# Altium schematic sheet Driver.SchDoc(Driver)
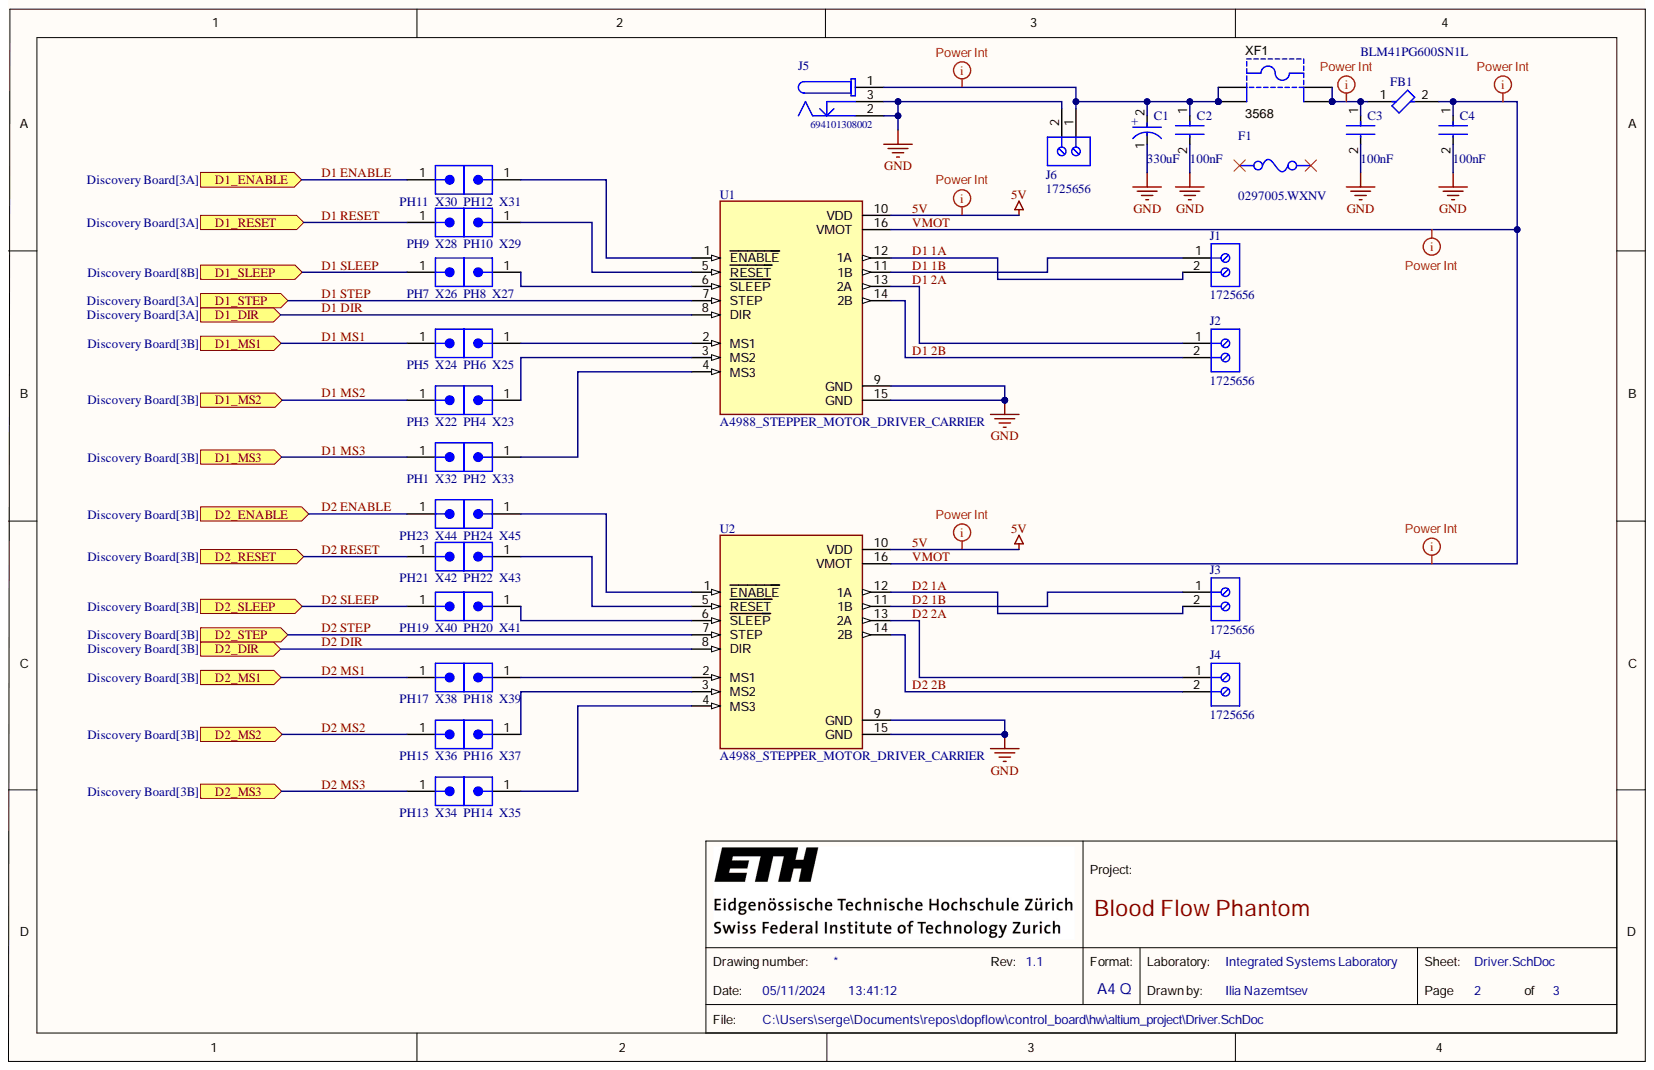
[diagram p0: Driver.SchDoc]

## Parts
C1  330uF  [FP-RAD-TH-D_10_0_5-L_12_5-MFG]
C2  100nF  [FP-CL31-IPC_C]
C3  100nF  [FP-CL31-IPC_C]
C4  100nF  [FP-CL31-IPC_C]
F1  0297005.WXNV  [FP-0297-MFG]
FB1  BLM41PG600SN1L  [FP-BLM41-0_2-IPC_B]
J1  1725656  [PHOE-1725656-2]
J2  1725656  [PHOE-1725656-2]
J3  1725656  [PHOE-1725656-2]
J4  1725656  [PHOE-1725656-2]
J5  694101308002  [694101308002]
J6  1725656  [PHOE-1725656-2]
U1  A4988_STEPPER_MOTOR_DRIVER_CARRIER  [MODULE_A4988_STEPPER_MOTOR_DRIVER_CARRIER]
U2  A4988_STEPPER_MOTOR_DRIVER_CARRIER  [MODULE_A4988_STEPPER_MOTOR_DRIVER_CARRIER]
X22  PH3  [68000-101HLF]
X23  PH4  [68000-101HLF]
X24  PH5  [68000-101HLF]
X25  PH6  [68000-101HLF]
X26  PH7  [68000-101HLF]
X27  PH8  [68000-101HLF]
X28  PH9  [68000-101HLF]
X29  PH10  [68000-101HLF]
X30  PH11  [68000-101HLF]
X31  PH12  [68000-101HLF]
X32  PH1  [68000-101HLF]
X33  PH2  [68000-101HLF]
X34  PH13  [68000-101HLF]
X35  PH14  [68000-101HLF]
X36  PH15  [68000-101HLF]
X37  PH16  [68000-101HLF]
X38  PH17  [68000-101HLF]
X39  PH18  [68000-101HLF]
X40  PH19  [68000-101HLF]
X41  PH20  [68000-101HLF]
X42  PH21  [68000-101HLF]
X43  PH22  [68000-101HLF]
X44  PH23  [68000-101HLF]
X45  PH24  [68000-101HLF]
XF1  3568  [KSTN-3568_V]

## Ports
D1_DIR, D1_ENABLE, D1_MS1, D1_MS2, D1_MS3, D1_RESET, D1_SLEEP, D1_STEP, D2_DIR, D2_ENABLE, D2_MS1, D2_MS2, D2_MS3, D2_RESET, D2_SLEEP, D2_STEP

## Nets
GND: C1.1 C2.2 C3.2 C4.2 J5.2 J5.3 J6.2 U1.9(GND) U1.15(GND) U2.9(GND) U2.15(GND)
5V: U1.10(VDD) U2.10(VDD)
D1 1A: J1.2 U1.12(1A)
D1 1B: J1.1 U1.11(1B)
D1 2A: J2.1 U1.13(2A)
D1 2B: J2.2 U1.14(2B)
D1 DIR: U1.8(DIR) ; port D1_DIR
D1 ENABLE: X30.1 ; port D1_ENABLE
D1 MS1: X24.1 ; port D1_MS1
D1 MS2: X22.1 ; port D1_MS2
D1 MS3: X32.1 ; port D1_MS3
D1 RESET: X28.1 ; port D1_RESET
D1 SLEEP: X26.1 ; port D1_SLEEP
D1 STEP: U1.7(STEP) ; port D1_STEP
D2 1A: J3.2 U2.12(1A)
D2 1B: J3.1 U2.11(1B)
D2 2A: J4.1 U2.13(2A)
D2 2B: J4.2 U2.14(2B)
D2 DIR: U2.8(DIR) ; port D2_DIR
D2 ENABLE: X44.1 ; port D2_ENABLE
D2 MS1: X38.1 ; port D2_MS1
D2 MS2: X36.1 ; port D2_MS2
D2 MS3: X34.1 ; port D2_MS3
D2 RESET: X42.1 ; port D2_RESET
D2 SLEEP: X40.1 ; port D2_SLEEP
D2 STEP: U2.7(STEP) ; port D2_STEP
VMOT: C4.1 FB1.2 U1.16(VMOT) U2.16(VMOT)
NetC1_2: C1.2 C2.1 J5.1 J6.1 XF1.1 XF1.2
NetC3_1: C3.1 FB1.1 XF1.3 XF1.4
NetU1_1: U1.1(ENABLE) X31.1
NetU1_2: U1.2(MS1) X25.1
NetU1_3: U1.3(MS2) X23.1
NetU1_4: U1.4(MS3) X33.1
NetU1_5: U1.5(RESET) X29.1
NetU1_6: U1.6(SLEEP) X27.1
NetU2_1: U2.1(ENABLE) X45.1
NetU2_2: U2.2(MS1) X39.1
NetU2_3: U2.3(MS2) X37.1
NetU2_4: U2.4(MS3) X35.1
NetU2_5: U2.5(RESET) X43.1
NetU2_6: U2.6(SLEEP) X41.1

## Library data of the parts
C1 = CMP-05427-001459-1: Aluminum Electrolytic Capacitors (Radial Lead Type) 330uF ±20% 35V; Impedance: 43mR; Lead Diameter: 0.6mm; Life (Hours): 6000Hour; Ripple Current: 1.33A; Capacitance: 330uF; Case/Package: Radial; Component Kind: Standard; Construction: RADIAL; Diameter: 10mm; Height: 12.5mm; Lead Pitch: 5mm; Library Name: Altium Content Vault; Max Operating Temperature: 105°C; Min Operating Temperature: -40°C; Packaging: Bulk; REACH SVHC: No SVHC; Ripple Current (AC): 1.33A; RoHS Compliant: Yes; Series: FR Radial; Termination: Radial; Tolerance: 20%; Voltage: 35V; Voltage Rating: 35V
C2-C4 = CMP-13271-001731-1: Cap Ceramic 100nF 50V X7R ±10% SMD 1206 +125°C Embossed T/R; Capacitance: 100nF; Case/Package: 1206; Component Kind: Standard; Depth: 1.6mm; Dielectric: X7R; Height: 0.85mm; Lead Free: Lead Free; Length: 3.2mm; Library Name: Altium Content Vault; Max Operating Temperature: 125°C; Min Operating Temperature: -55°C; Mount: Surface Mount; Package Quantity: 15000; Packaging: Tape and Reel; Radiation Hardening: No; Thickness: 0.037inch; Tolerance: 10%; Voltage: 50V; Voltage Rating: 50V; Voltage Rating (DC): 50V; Width: 0.063inch
F1 = CMP-08605-000073-1: FUSE AUTO 5A 32VDC BLADE MINI; Manufacturer: Littelfuse Inc; Fuse Blow Speed: Fast; Fuse I2T: 25A2Sec; Fuse Interrupt Dc Current: 1000A; Fuse Voltage Drop: 129mV; Max Fuse Dc Voltage: 32V; Resistance: 17.75mΩ; Value: 17.75mΩ; Automotive: Yes; Category: Fuse; Component Kind: Standard; ComponentLink2Description: Datasheet; ComponentLink2URL: https://www.littelfuse.com/~/media/automotive/datasheets/fuses/passenger-car-and-commercial-vehicle/blade-fuses/littelfuse_mini_datasheet.pdf; Device Class L1: Circuit Protection; Device Class L2: Fuses; Device Class L3: unset; Digikey Description: FUSE AUTO 5A 32VDC BLADE MINI; Fuse Current: 5A; Lead Free: Yes; Library Name: Altium Content Vault; Manufacturer Part Number: 0297005.WXNV; Mouser Description: Automotive Fuses 32V 5A Mini; Octopart: https://octopart.com/0297005.wxnv-littelfuse-566870; Package: 0297; RoHS: TRUE; Temperature: -40°C to +125°C; Temperature Range High: +125°C; Temperature Range Low: -40°C; Voltage Rating: 32V
FB1 = CMP-0686-00754-3: Ferrite Bead, 1806, 60Ω @ 100MHz, 0.009Ω, 6A; Manufacturer Series: BLM41P; DC Resistance (DCR): 9mR; Impedance: 60R; Number of Circuits: 1; Shielding: Unshielded; Tolerance: 25%; Case Code (Imperial): 1806; Case Code (Metric): 4516; Case/Package: 1806; Component Kind: Standard; Contact Plating: Tin; Core Material: Ferrite; Current: 6A; Current Rating: 6A; DC Current: 6A; DC Resistance-Max: 9mR; Depth: 1.6mm; Frequency: 100MHz; Height: 1.6mm; Inductor Type: FERRITE BEAD; Lead Free: Lead Free; Length: 4.5mm; Library Name: Altium Content Vault; Material: Ferrite; Max Current Rating: 6A; Max DC Current: 6A; Max Operating Temperature: 125°C; Military Standard: Not; Min Operating Temperature: -55°C; Number of Functions: 1; Number of Lines: 1; Number of Pins: 2; Package Quantity: 1; Packaging: Tape and Reel; Radiation Hardening: No; REACH SVHC: No SVHC; RoHS Compliant: Yes; Series: BLM41P; Terminal Finish: Tin; Termination: SMD/SMT; Test Frequency: 100MHz; Width: 1.6mm
J1-J4 J6 = CMP-2000-05299-1: Male Header, Pitch 2.54 mm, 1 x 2 Position, Height 9 mm, Tail Length 3.5 mm; Manufacturer: Phoenix Contact; Content: 250pc(s); Industrial packaging: Yes; Number of contacts: 2; Pitch: 2.54mm; Colour: Green; Component Kind: Standard; Datasheet URL: http://datasheet.octopart.com/1725656-Phoenix-Contact-datasheet-5302866.pdf; Datasheet Version: Apr-08; Library Name: Altium Content Vault; Manufacturer part No.: 1725656; Manufacturer URL: http://www.phoenixcontact.com/; Type: MPT0,5/2-2,54
J5 = CMP-1502-00182-1: DC Power Jack Connector Low Profile WR-DC, Male, Right Angled, THT, 2 A, 12 V; Manufacturer: Wurth Elektronik; Opening Diameter: 2.8mm; Plug Inner Diameter: 0.75mm; Plug Outer Diameter: 2.35mm; Rated Current: 2A; Working Voltage: 12V; Component Kind: Standard; Datasheet URL: http://katalog.we-online.de/em/datasheet/694101308002.pdf; Gender: Male; Library Name: Altium Content Vault; Manufacturer URL: http://www.we-online.de; Mounting Technology: THT; Operating Temperature: -40to85degC; Orientation: RightAngled; Package Reference: 694101308002; Packaging: Bag; Part Number: 694101308002; Publisher: WurthElektronik
U1 U2 = A4988_STEPPER_MOTOR_DRIVER_CARRIER: Stepper motor controler; IC: A4988; 1A; Uin mot: 8Ã·35V; Availability: Unavailable; Component Kind: Standard; Library Name: A4988_STEPPER_MOTOR_DRIVER_CARRIER.SchLib; MP: A4988_STEPPER_MOTOR_DRIVER_CARRIER; Package: None; Price: None
X22-X45 = CMP-00008-5: 1 Positions Header, Unshrouded Connector  Through Hole Gold or Gold, GXT™; Manufacturer: Amphenol FCI; Connector Type: Male Pin; Current Rating: 3A; Gender: Male; Insulation Resistance: 5GR; Mating Post Length: 5.84mm; Max Voltage Rating (AC): 1.5kV; Number of Contacts: 1; Pitch: 2.54mm; Value: 1000Meg; Colour: Black; Component Kind: Standard; ComponentLink1Description: 68000-274334-5147816.pdf; ComponentLink1URL: https://datasheet.ciiva.com/5147/68000-274334-5147816.pdf; ComponentLink2Description: 70236886-14643004.pdf; ComponentLink2URL: https://datasheet.ciiva.com/14643/70236886-14643004.pdf; ComponentLink3Description: 68000-10150538.pdf; ComponentLink3URL: https://datasheet.ciiva.com/10150/68000-10150538.pdf; ComponentLink4Description: 68000-731073.pdf; ComponentLink4URL: https://datasheet.ciiva.com/731/68000-731073.pdf; Contact Gender: Male; Contact Material: Bronze; Contact Mating Length: 0.23inch; Contact Plating: Nickel,Gold,GXT; Depth: 2.41mm; Flammability Rating: UL94 V-0; Housing Colour: Black; Housing Material: Thermoplastic; Length: 2.54mm; Library Name: ETHZ Vault; Manufacturer Part Number: 68000-101HLF; Max Operating Temperature: 125°C; Min Operating Temperature: -65°C; Number of Positions: 1; Number of Rows: 1; Orientation: Straight; Package Quantity: 100; Packaging: Bulk; Radiation Hardening: No; Simulation: SIM-001-0001-1; Supplier: Digikey; Supplier Part Number: 609-3460-ND; Termination: Solder; Termination Post Length: 2.41mm; Voltage Rating: 1.5kV; Voltage Rating (DC): 1.5kV
XF1 = CMP-2000-05464-1: Fuse Holder, 20 A, 500 V, -50 to 145 degC, 4-Pin THD, RoHS; Manufacturer: Keystone; Component Kind: Standard; Datasheet URL: https://datasheet.ciiva.com/16294/m60-2p40-16294706.pdf; Datasheet Version: 04/2013; Library Name: Altium Content Vault; Manufacturer URL: http://www.keyelco.com/; Mounting Technology: ThroughHole; Package Description: 4-Pin Through Hole Device, Body 16 x 6.73 mm, Pitch 9.9 mm; Package Reference: 3568
